# Altium schematic sheet IC_Schema.SchDoc(IC_Schema)
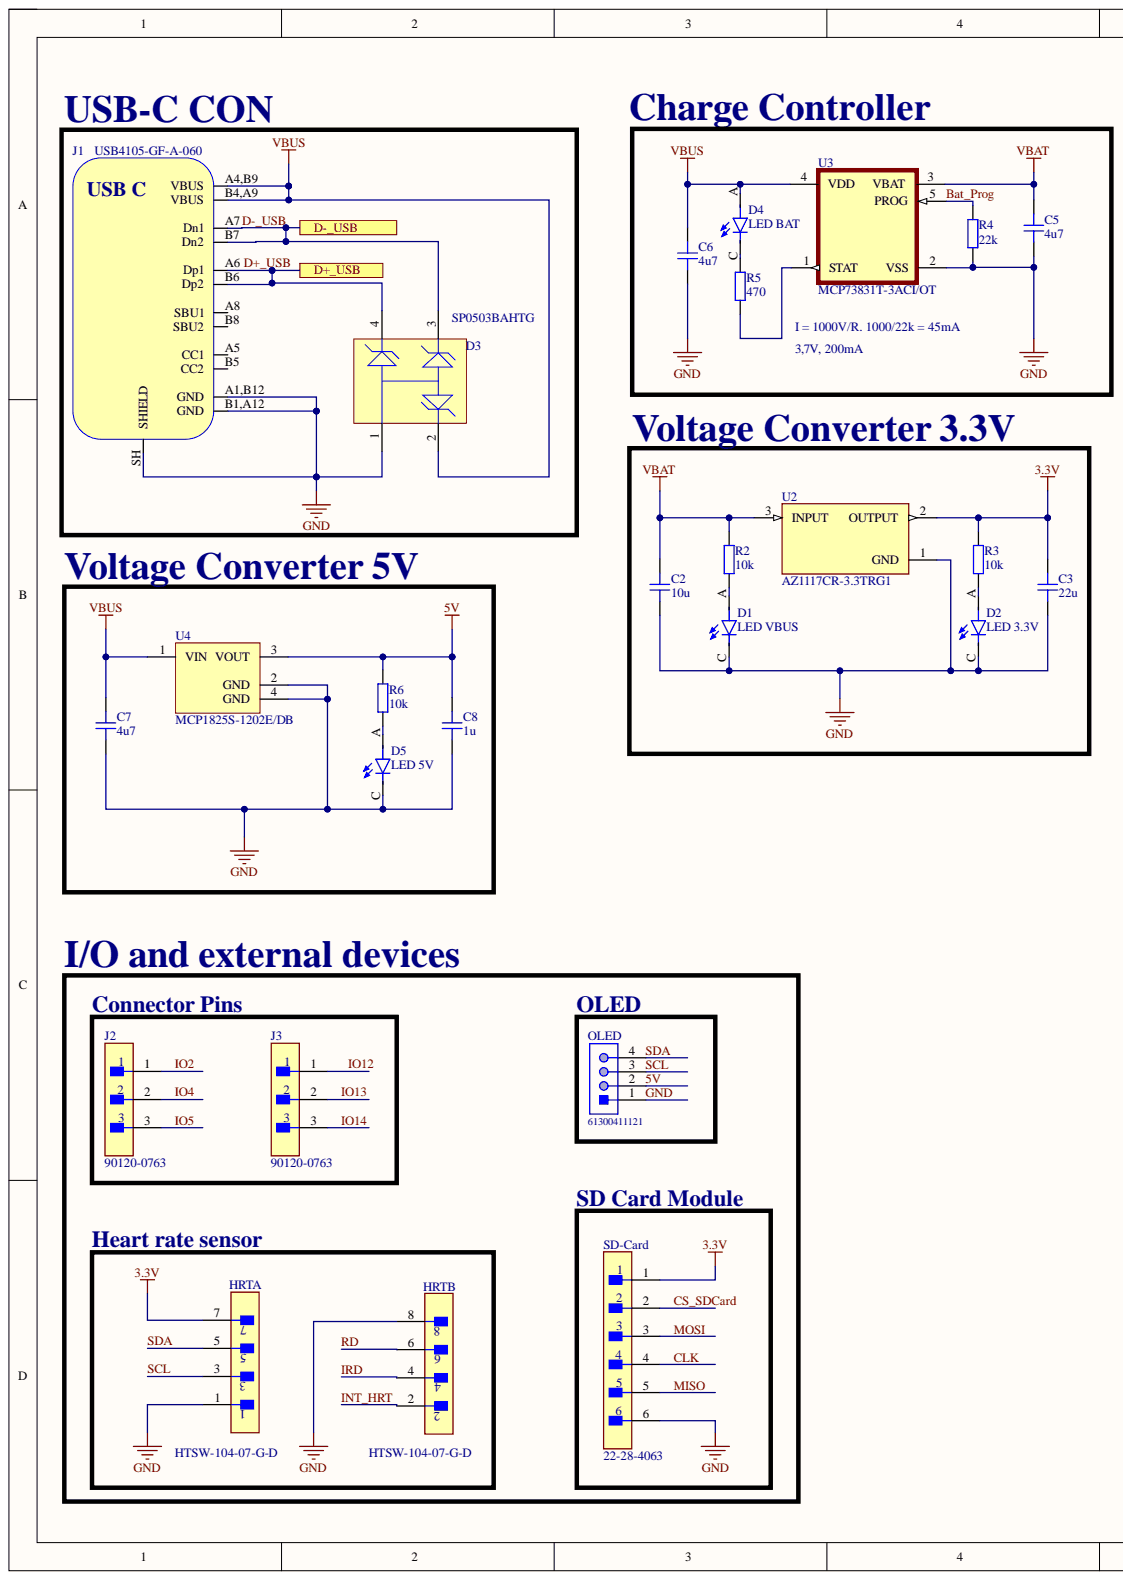
[diagram p0: IC_Schema.SchDoc, left]
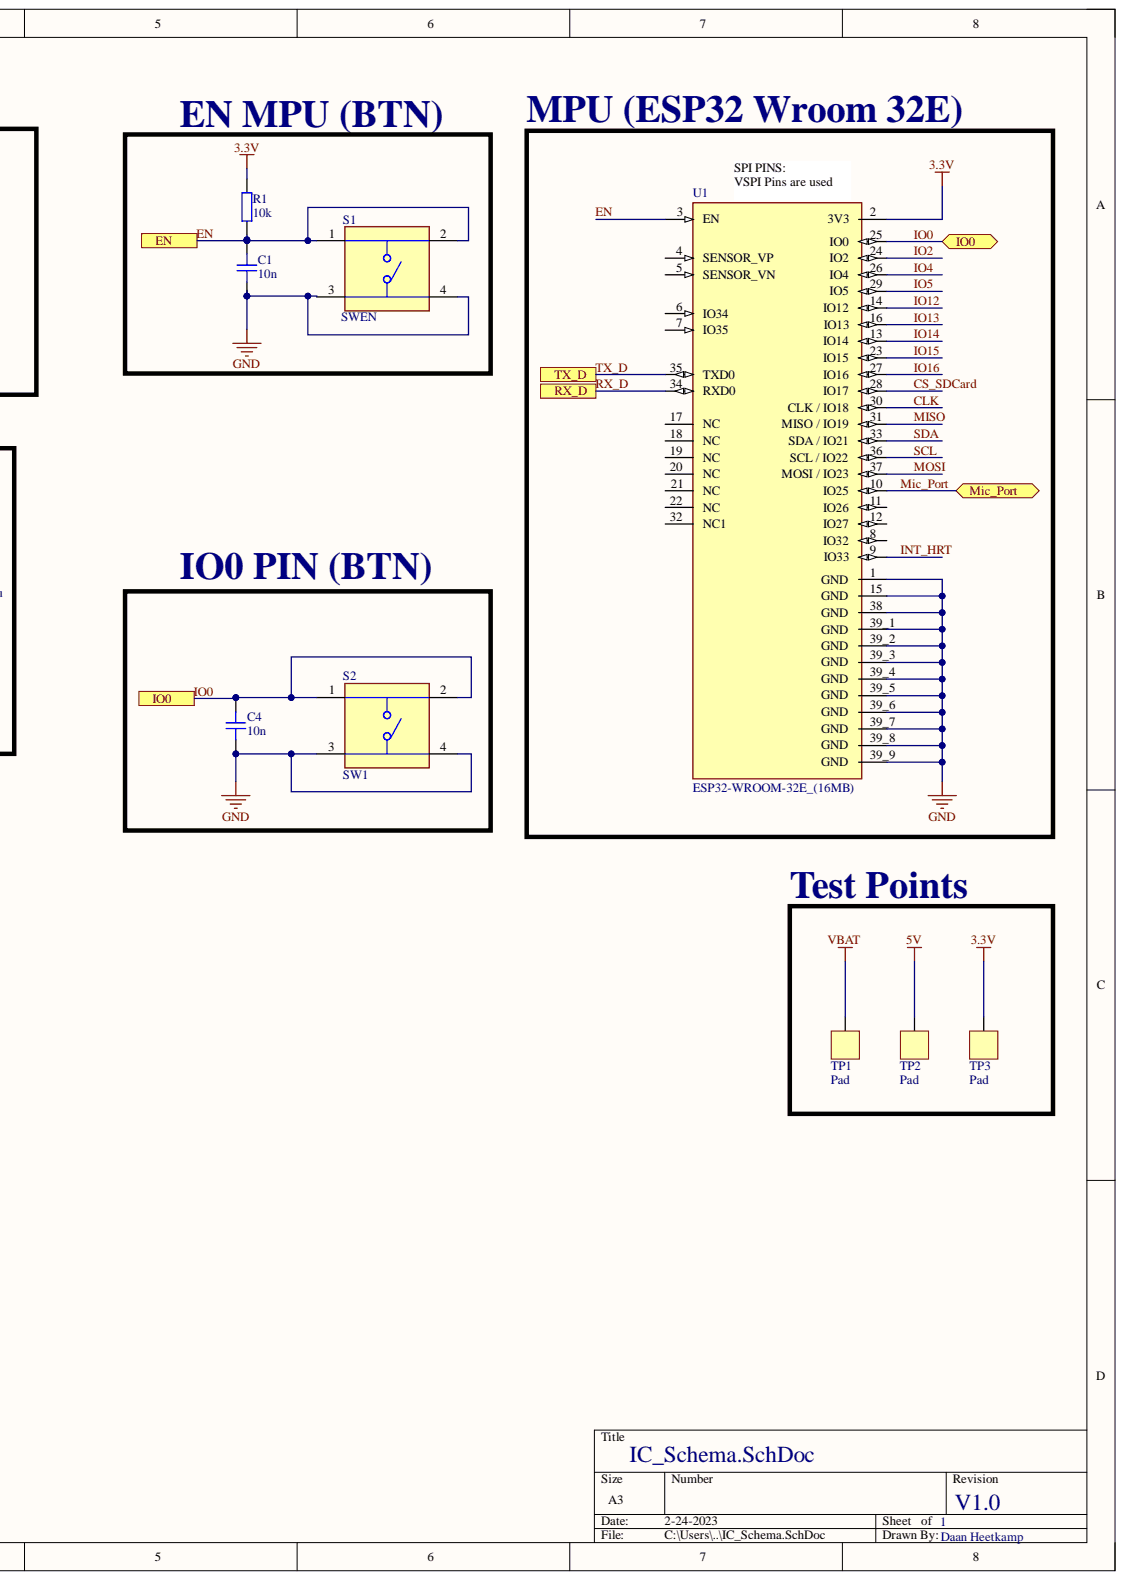
[diagram p1: IC_Schema.SchDoc, right]

## Parts
C1  10n  [CAP_1206]
C2  10u  [CAP_1206]
C3  22u  [CAP_1206]
C4  10n  [CAP_1206]
C5  4u7  [CAP_1206]
C6  4u7  [CAP_1206]
C7  4u7  [CAP_1206]
C8  1u  [CAP_1206]
D1  LED VBUS  [LED_1206]
D2  LED 3.3V  [LED_1206]
D3  SP0503BAHTG  [LFUS-SOT143-4_V]
D4  LED BAT  [LED_1206]
D5  LED 5V  [LED_1206]
HRT  HTSW-104-07-G-D  [FP-HTSW-104-07-G-D-MFG]  (parts A,B)
J1  USB4105-GF-A-060  [USB4105-GF-A-060]
J2  90120-0763  [FP-90120-0763-MFG]
J3  90120-0763  [FP-90120-0763-MFG]
OLED  61300411121  [61300411121]
R1  10k  [RES_1206]
R2  10k  [RES_1206]
R3  10k  [RES_1206]
R4  22k  [RES_1206]
R5  470  [RES_1206]
R6  10k  [RES_1206]
S1  SWEN  [Switch/Button 4 Pin Through Hole]
S2  SW1  [Switch/Button 4 Pin Through Hole]
SD-Card  22-28-4063  [FP-22-28-4063-MFG]
TP1  Pad  [PAD_SQ_2MM]
TP2  Pad  [PAD_SQ_2MM]
TP3  Pad  [PAD_SQ_2MM]
U1  ESP32-WROOM-32E_(16MB)  [XCVR_ESP32-WROOM-32E_(16MB)]
U2  AZ1117CR-3.3TRG1  [FP-SOT89-MFG]
U3  MCP73831T-3ACI/OT  [SOT95P270X145-5N]
U4  MCP1825S-1202E/DB  [SOT-223-DB3_N]

## Ports
D+_USB, D-_USB, EN, IO0, Mic_Port, RX_D, TX_D

## Nets
3.3V: C3.2 HRT.7 R1.1 R3.2 SD-Card.1 TP3.1 U1.2(3V3) U2.2
VBAT: C2.2 C5.2 R2.2 TP1.1 U2.3 U3.3(VBAT)
VBUS: C6.2 C7.2 D3.2 D4.A J1.A4,B9(VBUS) J1.B4,A9(VBUS) U3.4(VDD) U4.1(VIN)
5V: C8.2 OLED.2 R6.2 TP2.1 U4.3(VOUT)
Bat_Prog: R4.2 U3.5(PROG)
CLK: SD-Card.4 U1.30(CLK_/_IO18)
CS_SDCard: SD-Card.2 U1.28(IO17)
D+_USB: D3.4 J1.A6(Dp1) J1.B6(Dp2) ; port D+_USB
D-_USB: D3.3 J1.A7(Dn1) J1.B7(Dn2) ; port D-_USB
EN: C1.2 R1.2 S1.1 S1.2 U1.3(EN) ; port EN
GND: C1.1 C2.1 C3.1 C4.1 C5.1 C6.1 C7.1 C8.1 D1.C D2.C D3.1 D5.C HRT.1 HRT.8 J1.A1,B12(GND) J1.B1,A12(GND) J1.SH(SHIELD) OLED.1 R4.1 S1.3 S1.4 S2.3 S2.4 SD-Card.6 U1.1(GND) U1.15(GND) U1.38(GND) U1.39_1(GND) U1.39_2(GND) U1.39_3(GND) U1.39_4(GND) U1.39_5(GND) U1.39_6(GND) U1.39_7(GND) U1.39_8(GND) U1.39_9(GND) U2.1 U3.2(VSS) U4.2(GND) U4.4(GND)
INT_HRT: HRT.2 U1.9(IO33)
IO0: C4.2 S2.1 S2.2 U1.25(IO0) ; port IO0
IO2: J2.1 U1.24(IO2)
IO4: J2.2 U1.26(IO4)
IO5: J2.3 U1.29(IO5)
IO12: J3.1 U1.14(IO12)
IO13: J3.2 U1.16(IO13)
IO14: J3.3 U1.13(IO14)
IO15: U1.23(IO15)
IO16: U1.27(IO16)
IRD: HRT.4
Mic_Port: U1.10(IO25) ; port Mic_Port
MISO: SD-Card.5 U1.31(MISO_/_IO19)
MOSI: SD-Card.3 U1.37(MOSI_/_IO23)
RD: HRT.6
RX_D: U1.34(RXD0) ; port RX_D
SCL: HRT.3 OLED.3 U1.36(SCL_/_IO22)
SDA: HRT.5 OLED.4 U1.33(SDA_/_IO21)
TX_D: U1.35(TXD0) ; port TX_D
NetD1_A: D1.A R2.1
NetD2_A: D2.A R3.1
NetD4_C: D4.C R5.2
NetD5_A: D5.A R6.1
NetR5_1: R5.1 U3.1(STAT)

Not connected: J1 A5(CC1) A8(SBU1) B5(CC2) B8(SBU2); U1 4(SENSOR_VP) 5(SENSOR_VN) 6(IO34) 7(IO35) 8(IO32) 11(IO26) 12(IO27) 17(NC) 18(NC) 19(NC) 20(NC) 21(NC) 22(NC) 32(NC1)

## Library data of the parts
C1-C8 = CAP_1206_50V: Capacitor SMD 1206 50V; Component Kind: Standard; Library Name: Schem_Lib_DaanHeetkamp..SchLib
D1 D2 D4 D5 = LED - 1206: Yellow 587nm LED Indication - Discrete 2V 1206 _3216 Metric_; MF: Lite-On Inc.; MP: LTST-C230KSKT; Availability: Unavailable; Component Kind: Standard; Package: 1206 Lite-On; Price: None; SnapEDA_Link: https://www.snapeda.com/parts/LTST-C230KSKT/Lite-On%20Inc./view-part/264912/?ref=snap; Library Name: Schem_Lib_DaanHeetkamp..SchLib
D3 = CMP-2000-05191-1: TVS Diode Array, 30 pF, 30 KV, -40 to 85 degC, 4-Pin SOT143, RoHS, Tape and Reel; Manufacturer: Littelfuse; Capacitance Value: 30pF; Clamping Voltage: 8V; Configuration: Triple; Direction Type: Uni-Directional; Leakage Current: 0.1uA; Number of Elements: 3; Product Depth (mm): 1.4mm; Product Height (mm): 1.05mm; Product Length (mm): 3.04mm; Rad Hardened: No; Reverse Stand-off Voltage: 5.5(Min)V; Working Voltage: 5.5V; Working Voltage (Max): 5.5V(Min); Clamping Voltage (Max): 8V; Component Kind: Standard; Datasheet URL: https://datasheet.ciiva.com/15143/getdatasheetpartid-116020-15143550.pdf; Datasheet Version: Dec-13; Leakage Current (Max): 0.1uA; Library Name: Altium Content Vault; Manufacturer URL: http://www.littelfuse.com/; Mounting: SurfaceMount; Mounting Style: SurfaceMount; Operating Temp Range: -40Cto85C; Operating Temperature Classification: Industrial; Operating Temperature Max Deg. C: 85C; Operating Temperature Min Deg. C: -40C; Package / Case: SOT-143; Package Type: SOT-143; Packaging: TapeandReel; Pin Count: 3+Tab; Polarity: Uni-Directional; Suppressor Type: TVS
HRT = CMP-02766-000066-1: CONN HEADER VERT 8POS 2.54MM; Manufacturer: Samtec; Conn Gender: Male; Conn Orientation: Straight; Current Rating: 6.3A; Number Of Contacts: 8; Voltage Rating: 550V; Automotive: No; Category: Conn; Component Kind: Standard; ComponentLink2Description: Datasheet; ComponentLink2URL: http://suddendocs.samtec.com/prints/htsw-xxx-xx-xxx-x-xx-xx-xx-mkt.pdf; Contact Material: Gold,Nickel; Device Class L1: Connectors; Device Class L2: Headers and Wire Housings; Device Class L3: unset; Digikey Description: CONN HEADER VERT 8POS 2.54MM; Footprint Url: http://suddendocs.samtec.com/prints/htsw-xxx-xx-xxx-x-xx-xx-xx-footprint.pdf; Height: 8.71mm; Lead Free: Yes; Library Name: Altium Content Vault; Manufacturer Part Number: HTSW-104-07-G-D; Number Of Rows: 2; Octopart: https://octopart.com/htsw-104-07-g-d-samtec-10613822; Package: HTSW-104-07-G-D; Pitch: 2.54mm; RoHS: TRUE; Temperature: -55°C to +125°C; Temperature Range High: +125°C; Temperature Range Low: -55°C
J1 = USB4105-GF-A-060: USB Connector Type C SMT 12 Pin; Manufacturer: GCT; Component Kind: Standard; Manufacturer Part Number: USB4105-GF-A-060; Library Name: Schem_Lib_DaanHeetkamp.SchLib
J2 J3 = CMP-04776-000084-1: CONN HEADER VERT 3POS 2.54MM; Manufacturer: Molex; Conn Gender: Male; Conn Orientation: Vertical; Current Rating: 3A; Number Of Contacts: 3; Voltage Rating: 350V; Automotive: No; Category: Conn; Component Kind: Standard; ComponentLink2Description: Datasheet; ComponentLink2URL: https://www.molex.com/webdocs/datasheets/pdf/en-us/0901200763_PCB_HEADERS.pdf; Contact Material: Gold,Nickel; Device Class L1: Connectors; Device Class L2: Headers and Wire Housings; Device Class L3: unset; Digikey Description: CONN HEADER VERT 3POS 2.54MM; Footprint Url: https://www.molex.com/pdm_docs/sd/901200763_sd.pdf; Height: 5.8mm; Lead Free: Yes; Library Name: Altium Content Vault; Manufacturer Part Number: 90120-0763; Mouser Description: Board-To-Board Connector, C-Grid III 90120 Series, Through Hole, Header, 3, 2.54 mm; Number Of Rows: 1; Octopart: https://octopart.com/90120-0763-molex-655433; Package: 90120-0763; Pitch: 2.54mm; RoHS: TRUE; Temperature: -55°C to +125°C; Temperature Range High: +125°C; Temperature Range Low: -55°C
OLED = CMP-1502-01064-1: THT Vertical Pin Header WR-PHD, Pitch 2.54 mm, Single Row, 4 pins; Manufacturer: Wurth Elektronik; Contact Resistance: 20mOhm; Gender: Male; Pin Number: 4; Pitch: 2.54mm; Rated Current: 3A; Withstanding Voltage: 500VAC; Working Voltage: 250VAC; Component Kind: Standard; Datasheet URL: https://katalog.we-online.de/em/datasheet/6130xx11121.pdf; Library Name: Altium Content Vault; Manufacturer URL: http://www.we-online.de; Mounting Technology: THT; Operating Temperature: -40to105degC; Orientation: Vertical; Package Reference: 61300411121; Packaging: Bag; Part Number: 61300411121; Row Number: Single; Publisher: WurthElektronik
R1-R6 = RES_1206: Resistor SMD 1206; Component Kind: Standard; Library Name: Schem_Lib_DaanHeetkamp..SchLib
S1 S2 = Switch/Button 4 Pin Through Hole: TS02-66-120-BK-260-LCR-D  6 x 6 mm, 12 mm Actuator Height, 260 gf, Black, Long Crimped, Through Hole, SPST, Tactile Switch; MF: CUI Devices; Availability: In Stock; Check_prices: https://www.snapeda.com/parts/TS02-66-120-BK-260-LCR-D/CUI+Devices/view-part/?ref=eda; Component Kind: Standard; CUI_purchase_URL: https://www.cuidevices.com/product/switches/tactile-switches/ts02-series?utm_source=snapeda.com&utm_medium=referral&utm_campaign=snapedaBOM; MP: TS02-66-120-BK-260-LCR-D; Package: None; Price: None; Purchase-URL: https://pricing.snapeda.com/search/part/TS02-66-120-BK-260-LCR-D/?ref=eda; SnapEDA_Link: https://www.snapeda.com/parts/TS02-66-120-BK-260-LCR-D/CUI+Devices/view-part/?ref=snap; Library Name: Schem_Lib_DaanHeetkamp.SchLib
SD-Card = CMP-04776-000885-1: CONN HEADER VERT 6POS 2.54MM; Connector Type: Male Pin; Contact Resistance: 20mR; Current Rating: 4A; Gender: Male; Glow Wire Compliant: Non-compliant; Max Voltage Rating (AC): 250V; Max Voltage Rating (DC): 250.25kV; Number of Circuits: 6; Color: Black; Component Kind: Standard; Contact Material: Brass; Contact Mating Length: 0.24inch; Contact Plating: Gold; Contact Type: Male Pin; Depth: 2.49mm; Flammability Rating: UL94 V-0; Housing Color: Black; Housing Material: Thermoplastic; Lead Free: Lead Free; Library Name: Altium Content Vault; Max Operating Temperature: 75°C; Min Operating Temperature: 0°C; Mount: Through Hole; Number of Contacts: 6; Number of Positions: 6; Number of Rows: 1; Orientation: Straight; Package Quantity: 1; Packaging: Bulk; Pitch: 2.54mm; Radiation Hardening: No; RoHS Compliant: Yes; Series: KK® 42375; Termination: Solder; Voltage Rating: 250V; Voltage Rating (DC): 250V
TP1-TP3 = PAD_SQ_2MM: Test Point Square 2mm; Component Kind: Standard; Library Name: Schem_Lib_DaanHeetkamp..SchLib
U1 = ESP32-WROOM-32E_(16MB): Bluetooth, WiFi 802.11b/g/n, Bluetooth v4.2 +EDR, Class 1, 2 and 3 Transceiver Module 2.4GHz ~ 2.5GHz Integrated, Trace Surface Mount; MF: Espressif Systems; MP: ESP32-WROOM-32E (16MB); Availability: Not in stock; Check_prices: https://www.snapeda.com/parts/ESP32-WROOM-32E%20(16MB)/Espressif+Systems/view-part/?ref=eda; Component Kind: Standard; Package: None; Price: None; SnapEDA_Link: https://www.snapeda.com/parts/ESP32-WROOM-32E%20(16MB)/Espressif+Systems/view-part/?ref=snap; Library Name: Schem_Lib_DaanHeetkamp..SchLib
U2 = CMP-12756-000007-1: IC REG LINEAR 3.3V 800MA SOT89; Manufacturer: Diodes Inc.; Accuracy Percentage: 1%; Dropout Voltage: 1.2V; Max Junction Temp: +125°C; Max Supply Voltage: 15V; Nominal Supply Current: 4mA; Number Of Outputs: 1; Output Current: 1.35A; Output Type: Fixed; Automotive: No; Category: IC; Component Kind: Standard; ComponentLink2Description: Datasheet; ComponentLink2URL: https://www.diodes.com/assets/Datasheets/AZ1117C.pdf; Device Class L1: Integrated Circuits (ICs); Device Class L2: Power Management ICs; Device Class L3: Voltage Regulators - Linear; Digikey Description: IC REG LINEAR 3.3V 800MA SOT89; Footprint Url: https://www.diodes.com/assets/Package-Files/SOT89.pdf; Height: 1.6mm; Lead Free: Yes; Library Name: Altium Content Vault; Manufacturer Part Number: AZ1117CR-3.3TRG1; Mouser Description: DiodesZetex AZ1117CR-3.3TRG1, LDO Regulator, 800mA, 3.3 V, ±1% 3-Pin, SOT-89; Octopart: https://octopart.com/az1117cr-3.3trg1-diodes+inc.-30954805; Output Voltage: 3.3V; Package: SOT89; RoHS: TRUE; Temperature: -20°C to +125°C; Temperature Range High: +125°C; Temperature Range Low: -20°C
U3 = MCP73831T-3ACI/OT: Charger IC Lithium Ion/Polymer SOT-23-5; MF: Microchip; Arrow_Electronics-Purchase-URL: https://snapeda.com/shop?store=Arrow+Electronics&id=1099789; Component Kind: Standard; Mouser-Purchase-URL: https://snapeda.com/shop?store=Mouser&id=1099789; MP: MCP73831T-3ACI/OT; Package: SOT-23-5 Microchip; SnapEDA_Link: https://www.snapeda.com/parts/MCP73831T-3ACI/OT/Microchip/view-part/1099789/?ref=snap; Library Name: Schem_Lib_DaanHeetkamp.SchLib
U4 = CMP-0136-00465-1: 500 mA, Low Voltage, Low Quiescent Current LDO Regulator, 3-Pin SOT-223, Extended Temperature; Component Kind: Standard; DatasheetVersion: revB, Feb-2008; Library Name: Altium Content Vault; PackageDescription: 3-Lead Plastic Small Outline Transistor (DB) [SOT-223]; PackageReference: SOT-223-DB3; PackageVersion: revBB, Aug-2009
